# Altium schematic sheet Node_Power.SchDoc(Node_Power)
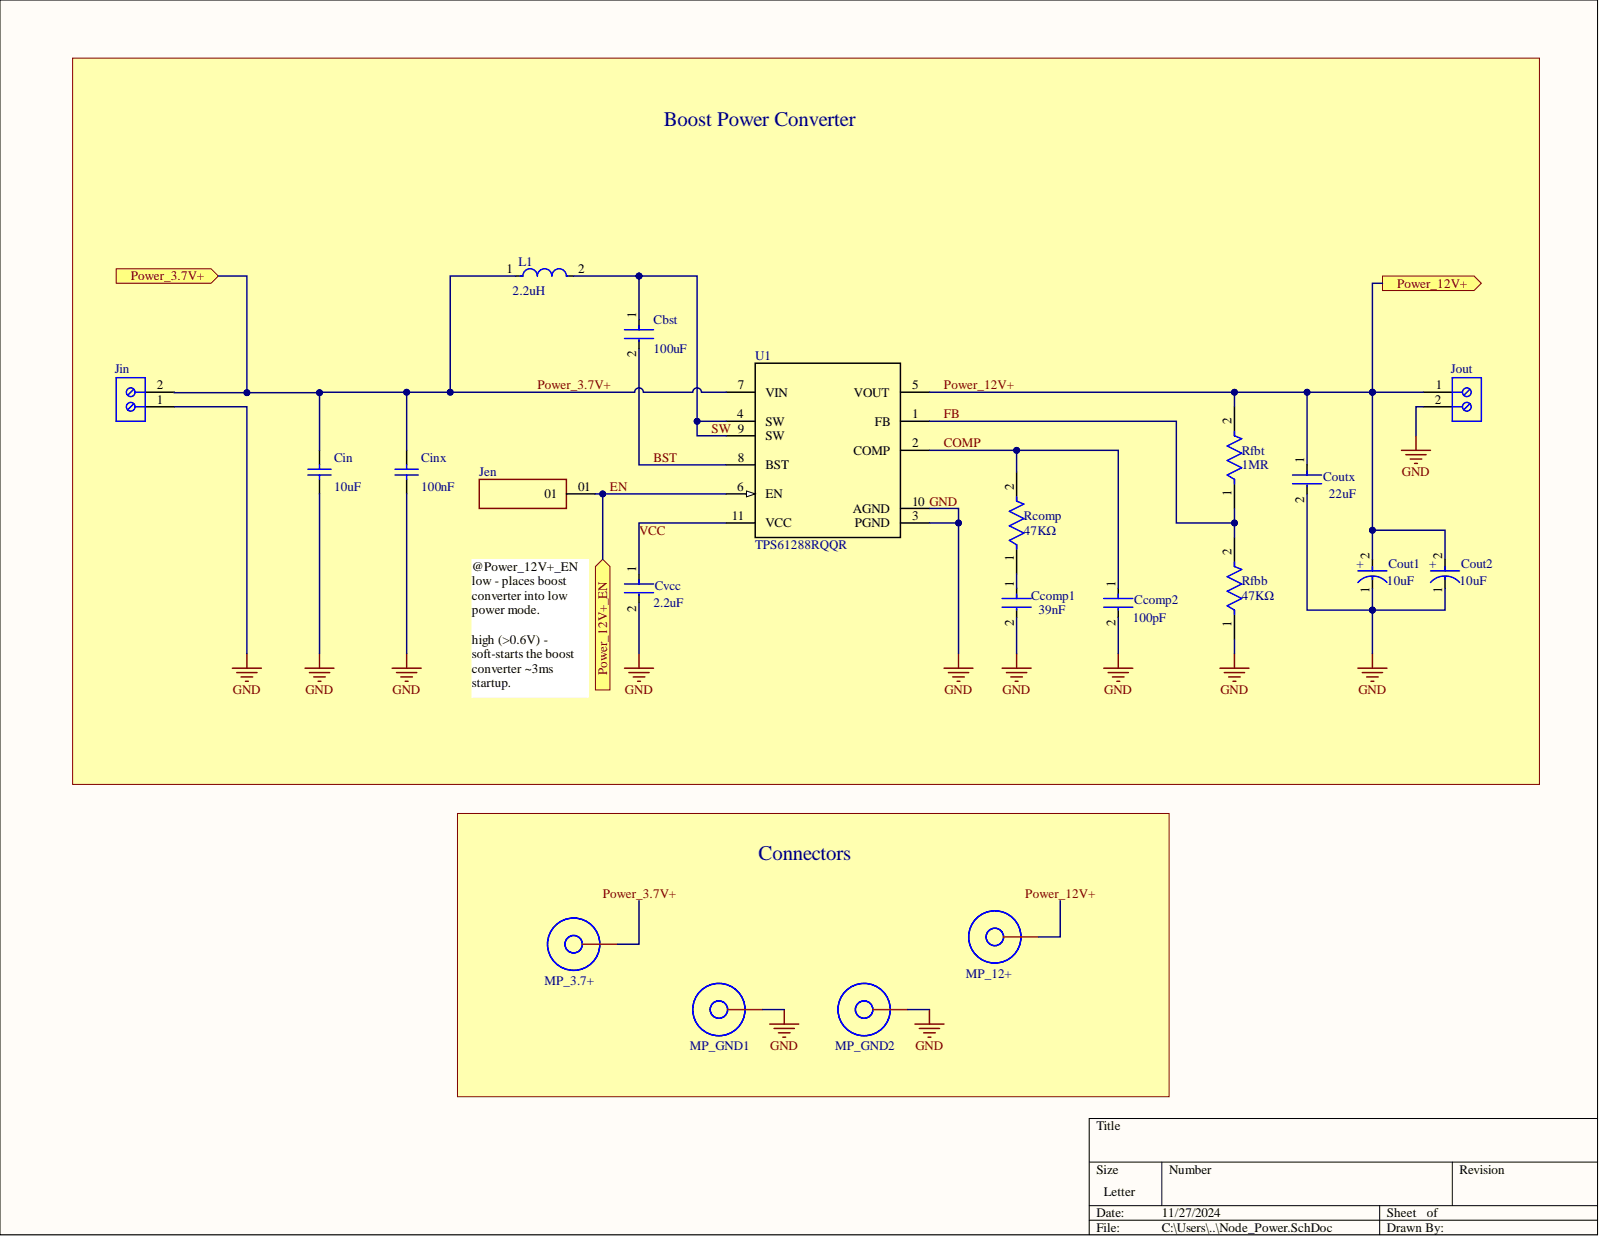
[diagram p0: Node_Power.SchDoc]

## Parts
Cbst  value 100uF  Capacitance: 100uF  [FP-GRM32-0_3-MFG]  (hidden comment: GRM32ER60J107ME20L)
Ccomp1  Capacitance: 39nF  [FP-GRM033-0_03-MFG]  (hidden comment: GRM033R60J393KE19D)
Ccomp2  Capacitance: 100pF  [FP-GRM033-0_03-IPC_C]  (hidden comment: GRM0335C1E101JA01D)
Cin  value 10uF  [CAPC0805(2012)155_L]  (hidden comment: CAP 10uF 6.3V 0805(2012))
Cinx  value 100nF  [CAPC1005X55X25ML05T10]  (hidden comment: VJ0402G104KXQCW1BC)
Cout1  Capacitance: 10uF  [FP-OS-CON-SMT-B6-MFG]  (hidden comment: 20SVPA10M)
Cout2  Capacitance: 10uF  [FP-OS-CON-SMT-B6-MFG]  (hidden comment: 20SVPA10M)
Coutx  Capacitance: 22uF  [FP-GRM21B-0_15-IPC_B]  (hidden comment: GRM21BE70G226ME51L)
Cvcc  Capacitance: 2.2uF  [FP-GRM188-0_1-e0_2_0_5-MFG]  (hidden comment: GRM188R71A225KE15D)
Jen  BCS-101-L-S-TE  [SAMTEC_BCS-101-L-S-TE]  (value not drawn)
Jin  691214110002  [691214110002]  (value not drawn)
Jout  691214110002  [691214110002]  (value not drawn)
L1  Inductance: 2.2uH  [FP-IHLP-5050CE-FP_3_175x3-MFG]  (hidden comment: IHLP5050CEER2R2M01)
MP_3.7+  Pad  [Pad]  (value not drawn)  (no BOM)
MP_12+  Pad  [Pad]  (value not drawn)  (no BOM)
MP_GND1  Pad  [Pad]  (value not drawn)  (no BOM)
MP_GND2  Pad  [Pad]  (value not drawn)  (no BOM)
Rcomp  value 47KΩ  Resistance: 47KΩ  [FP-RT0805-MFG]  (hidden comment: RT0805BRD0747KL)
Rfbb  value 47KΩ  Resistance: 47KΩ  [FP-RT0805-MFG]  (hidden comment: RT0805BRD0747KL)
Rfbt  Resistance: 1MR  [FP-CRCW0402-e3-MFG]  (hidden comment: CRCW04021M00FKED)
U1  TPS61288RQQR  [RQQ0011A-MFG]

## Ports
Power_3.7V+, Power_12V+, Power_12V+_EN

## Nets
BST: Cbst.2 U1.8(BST)
COMP: Ccomp2.1 Rcomp.2 U1.2(COMP)
EN: Jen.01 U1.6(EN) ; port Power_12V+_EN
FB: Rfbb.2 Rfbt.1 U1.1(FB)
GND: Ccomp1.2 Ccomp2.2 Cin.1 Cinx.1 Cout1.1 Cout2.1 Coutx.2 Cvcc.2 Jin.1 Jout.2 MP_GND1.1 MP_GND2.1 Rfbb.1 U1.3(PGND) U1.10(AGND)
Power_3.7V+: Cin.2 Cinx.2 Jin.2 L1.1 MP_3.7+.1 U1.7(VIN) ; port Power_3.7V+
Power_12V+: Cout1.2 Cout2.2 Coutx.1 Jout.1 MP_12+.1 Rfbt.2 U1.5(VOUT) ; port Power_12V+
SW: Cbst.1 L1.2 U1.4(SW) U1.9(SW)
VCC: Cvcc.1 U1.11(VCC)
NetCcomp1_1: Ccomp1.1 Rcomp.1

## Library data of the parts
Cbst = CMP-2000-05259-2: CAP CER 100UF 6.3V X5R 1210; 3DS Part Number: 220-00002; Manufacturer: Murata; Temperature Coefficient: 15%; Tolerance: 20%; Automotive: No; Category: Cap; Component Kind: Standard; ComponentLink2Description: Datasheet; ComponentLink2URL: https://search.murata.co.jp/Ceramy/image/img/A01X/G101/ENG/GRM32ER60J107ME20-01.pdf; Device Class L1: Passive Components; Device Class L2: Capacitors; Device Class L3: Ceramic Capacitors; Digikey Description: CAP CER 100UF 6.3V X5R 1210; Height: 2.7mm; Ipc Land Pattern Name: CAPC320250X250; Lead Free: Yes; Library Name: Altium Content Vault; Manufacturer Part Number: GRM32ER60J107ME20L; Material: Ceramic; Octopart: https://octopart.com/grm32er60j107me20l-murata-197007; Package: GRM32-0.3; RoHS: TRUE; Temperature: -55°C to +85°C; Temperature Characteristic: X5R; Temperature Range High: +85°C; Temperature Range Low: -55°C; Voltage: 6.3V; Voltage Rating: 6.3V
Ccomp1 = CMP-2010-01663-2: Chip Multilayer Ceramic Capacitors for General Purpose, 0201, 39000pF, X5R, 15%, 10%, 6.3V; Case Code (Imperial): 0201; Case Code (Metric): 0603; Case/Package: 0201; Component Kind: Standard; Depth: 0.3mm; Dielectric: X5R; Height: 0.3mm; Length: 0.6mm; Library Name: Altium Content Vault; Max Operating Temperature: 85°C; Min Operating Temperature: -55°C; Mount: Surface Mount; Package Quantity: 15000; Packaging: Tape and Reel; Radiation Hardening: No; Termination: SMD/SMT; Thickness: 0.013inch; Tolerance: 10%; Voltage Rating: 6.3V; Voltage Rating (DC): 6.3V; Width: 0.3mm
Ccomp2 = CMP-2010-01306-2: Chip Multilayer Ceramic Capacitors for General Purpose, 0201, 100pF, C0G, 30ppm/°C, 5%, 25V; Case Code (Imperial): 0201; Case Code (Metric): 0603; Case/Package: 0201; Component Kind: Standard; Depth: 0.3mm; Dielectric: C0G; Height: 0.3mm; Lead Free: Lead Free; Length: 0.6mm; Library Name: Altium Content Vault; Max Operating Temperature: 125°C; Min Operating Temperature: -55°C; Mount: Surface Mount; Package Quantity: 15000; Packaging: Tape and Reel; Radiation Hardening: No; REACH SVHC: No SVHC; Termination: SMD/SMT; Thickness: 0.013inch; Tolerance: 5%; Voltage: 25V; Voltage Rating: 25V; Voltage Rating (DC): 25V; Width: 0.012inch
Cin = CMP-1036-04916-1: CAP 10uF 6.3V ±10% 0805 (2012 Metric) Thickness 1.55mm SMD; Case-EIA: 0805; Case-Metric: 2012; Tolerance: Â±10%; Component Kind: Standard; Library Name: Altium Content Vault; Max Thickness: 1.55 mm; Rated Voltage: 6.3 V; Technology: SMT
Cinx = CMP-2008-02013-1: Manufacturer: Vitramon; Manufacturer Series: VJ; Capacitor Type: Ceramic; Multilayer: Yes; Rated DC Voltage (URdc): 10V; Temperature Characteristics Code: X5R; Tolerance  (fill in as +-): 10%; Case/Package: 0402; Category: Ceramic Capacitors; Component Kind: Standard; ComponentLink1Description: https://datasheet.ciiva.com:443/pdfs/VipMasterIC/IC/BEYS/BEYSS01655/BEYSS01655-1.pdf?src-supplier=IHS+Markit; ComponentLink1URL: https://datasheet.ciiva.com:443/pdfs/VipMasterIC/IC/BEYS/BEYSS01655/BEYSS01655-1.pdf?src-supplier=IHS+Markit; ComponentLink2Description: https://datasheet.ciiva.com/3779/x5r-3779847.pdf?src-supplier=Arrow+Electronics; ComponentLink2URL: https://datasheet.ciiva.com/3779/x5r-3779847.pdf?src-supplier=Arrow+Electronics; ComponentLink3Description: https://datasheet.ciiva.com/16511/vjw1bcbascomseries-16511418.pdf?src-supplier=Future+Electronics; ComponentLink3URL: https://datasheet.ciiva.com/16511/vjw1bcbascomseries-16511418.pdf?src-supplier=Future+Electronics; Dielectric Material: Ceramic; JESD-609 Code: e3; Library Name: Altium Content Vault; Manufacturer Part Number: VJ0402G104KXQCW1BC; Max Operating Temperature: 125°C; Min Operating Temperature: -55°C; Mounting Technology: Surface Mount; Package Shape: Rectangular; Packaging: Tape and Reel; Part Number: VJ0402G104KXQCW1BC; Pins: 2; Pricing: 1=0.1, 10=0.054, 50=0.0368, 100=0.0317, 500=0.02296, 1000=0.02026, 2500=0.01739, 5000=0.01565 (USD); Reach SVHC Compliant: Yes; RoHS: Yes; RoHS Compliant: Yes; Stock: 24060; Supplier: Digikey; Supplier Part Number: 720-1640-1-ND; Terminal Finish: Tin; Termination: Wraparound; Tolerance (fill in as +-): 10%
Cout1 Cout2 = CMP-05428-001060-1: Conductive Polymer Aluminum Solid Capacitors (OS-CON) 10uF ±20% 20V; Dissipation Factor: 0.12%; ESR (Equivalent Series Resistance): 40mR; Leakage Current: 80uA; Life (Hours): 2000Hour; Ripple Current: 1.7A; Case/Package: Radial; Component Kind: Standard; Diameter: 5mm; Height: 5.9mm; Length: 5.3mm; Library Name: Altium Content Vault; Max Operating Temperature: 105°C; Min Operating Temperature: -55°C; Mount: Surface Mount; Package Quantity: 1500; Packaging: Tape and Reel; REACH SVHC: No SVHC; RoHS Compliant: Yes; Series: OS-CON™, SVPA; Termination: SMD/SMT; Tolerance: 20%; Voltage Rating: 20V; Voltage Rating (DC): 20V; Width: 5.3mm
Coutx = CMP-2007-03383-2: Chip Multilayer Ceramic Capacitors for General Purpose, 0805, 22uF, X7U, +22%/-56%, 20%, 4V; Case Code (Imperial): 0805; Case Code (Metric): 2012; Case/Package: 0805; Component Kind: Standard; Depth: 1.25mm; Dielectric: X7U; Height: 1.25mm; Lead Free: Lead Free; Length: 2mm; Library Name: Altium Content Vault; Material: Ceramic; Max Operating Temperature: 125°C; Min Operating Temperature: -55°C; Mount: Surface Mount; Package Quantity: 1; Packaging: Tape and Reel; Radiation Hardening: No; REACH SVHC: No SVHC; Termination: SMD/SMT; Thickness: 0.053inch; Tolerance: 20%; Voltage: 4V; Voltage Rating: 4V; Voltage Rating (DC): 4V; Width: 0.049inch
Cvcc = CMP-2006-02836-2: Chip Multilayer Ceramic Capacitors for General Purpose, 0603, 2.2uF, X7R, 15%, 10%, 10V; Case Code (Imperial): 0603; Case Code (Metric): 1608; Case/Package: 0603; Component Kind: Standard; Depth: 0.8mm; Dielectric: X7R; Height: 0.8mm; Lead Free: Lead Free; Length: 1.6mm; Library Name: Altium Content Vault; Material: Ceramic; Max Operating Temperature: 125°C; Min Operating Temperature: -55°C; Mount: Surface Mount; Number of Pins: 2; Package Quantity: 1; Packaging: Cut Tape; REACH SVHC: No SVHC; Termination: SMD/SMT; Thickness: 0.035inch; Tolerance: 10%; Voltage: 10V; Voltage Rating: 10V; Voltage Rating (DC): 10V; Weight: 7.1E-05oz; Width: 0.031inch
Jen = BCS-101-L-S-TE: MF: {'manufacturer': u'Samtec Inc.'}; Availability: Unavailable; Component Kind: Standard; Library Name: BCS-101-L-S-TE.IntLib; MP: BCS-101-L-S-TE; Package: None; Price: None
Jin Jout = CMP-1502-02464-2: NexarPartId: 18818288; Current: 10A; Number of Contacts: 2; Number of Levels: 1; Pitch: 3.5052mm; Plating: Brass, Steel; Stripping Length: -6mm; Voltage: 300V; Wire Gauge (Max): 24AWG; Wire Gauge (Min): 16AWG; Color: Blue, Green; Component Kind: Standard; Contact Material: Brass; Contact Plating: Brass, Tin; Current Rating: 10A; Height: 8.7mm; Housing Material: Nylon; Lead Pitch: 3.5mm; Length: 7.4mm; Library Name: Altium Content Vault; Max Operating Temperature: 105°C; Min Operating Temperature: -40°C; Mount: Through Hole; Number of Pins: 2; Number of Positions Per Level: 2; Number of Rows: 1; Packaging: Box; REACH SVHC: Unknown; RoHS: Compliant; Termination: Screw; Voltage Rating: 300V; Width: 7.9mm; Wire/Cable Gauge: 24AWG
L1 = CMP-02424-000486-1: Commercial Inductors, High Saturation Series 2.2uH 16A 8mΩ 20%; Core Material: Metal; DC Resistance (DCR): 8mR; Max DC Current: 29A; Self Resonant Frequency: 5MHz; Shielding: Shielded; Test Frequency: 100kHz; Case/Package: 5050; Component Kind: Standard; Contact Plating: Tin; Current Rating: 16A; Depth: 12.9mm; Height: 3.5mm; Height - Seated (Max): 0.138inch; Inductance Tolerance: 20%; Lead Free: Lead Free; Length: 13.58mm; Library Name: Altium Content Vault; Max Operating Temperature: 125°C; Military Standard: Not; Min Operating Temperature: -55°C; Mount: Surface Mount; Number of Pins: 2; Package Quantity: 500; Packaging: Tape and Reel; Radiation Hardening: No; RMS Current (Irms): 16A; Saturation Current: 29A; Termination: SMD/SMT; Tolerance: 20%; Width: 0.508inch
Rcomp Rfbb = CMP-2001-01461-2: RES SMD 47K OHM 0.1% 1/8W 0805; Manufacturer: Yageo; Power Rating: 0.125W; Temperature Coefficient: 25 ppm/°C; Tolerance: 0.0025; Voltage Rating: 150V; Automotive: No; Category: Res; Component Kind: Standard; ComponentLink2Description: Datasheet; ComponentLink2URL: http://www.yageo.com/documents/recent/PYu-RT_1-to-0.01_RoHS_L_9.pdf; Composition: Thin Film; Device Class L1: Passive Components; Device Class L2: Resistors; Device Class L3: Chip SMD Resistors; Digikey Description: RES SMD 47K OHM 0.1% 1/8W 0805; Footprint Url: http://www.yageo.com/exep/pages/download/literatures/PYu-R_Mount_10.pdf; Height: 0.6mm; Ipc Land Pattern Name: RESC200125X50; Lead Free: Yes; Library Name: Altium Content Vault; Manufacturer Part Number: RT0805BRD0747KL; Mouser Description: Thin Film Resistors - SMD 1/8W 47K ohm .1% 25ppm; Octopart: https://octopart.com/rt0805brd0747kl-yageo-1273925; Package: RT0805; RoHS: TRUE; Series: RT; Temperature: -55°C to +155°C; Temperature Range High: +155°C; Temperature Range Low: -55°C
Rfbt = CMP-2002-08314-2: RES Thick Film, 1MΩ, 1%, 0.063W, 100ppm/°C, 0402; Max Power Dissipation: 63mW; Voltage Rating: 50V; Case Code (Imperial): 0402; Case Code (Metric): 1005; Case/Package: 0402; Component Kind: Standard; Composition: Thick Film; Contact Plating: Tin; Depth: 0.5mm; Features: Automotive AEC-Q200; Height: 0.35mm; Lead Free: Lead Free; Length: 1mm; Library Name: Altium Content Vault; Max Operating Temperature: 155°C; Military Standard: Not; Min Operating Temperature: -55°C; Number of Pins: 2; Number of Terminations: 2; Package Quantity: 10; Packaging: Tape and Reel; Power Rating: 63mW; Radiation Hardening: No; Temperature Coefficient: 100ppm/°C; Termination: SMD/SMT; Tolerance: 1%; Voltage Rating (DC): 50V; Weight: 2.3E-05oz; Width: 0.02inch
U1 = TPS61288RQQR: No Description Available; Mfr_Name: Texas Instruments; Component Kind: Standard; Library Name: TPS61288RQQR.IntLib; RefDes: RefDes; TYPE: TYPE
MP_3.7+ MP_12+ MP_GND1 MP_GND2 = Pad: Library Name: 3.7V_12V_Boost.SchLib
